# Altium schematic sheet CORE.SchDoc
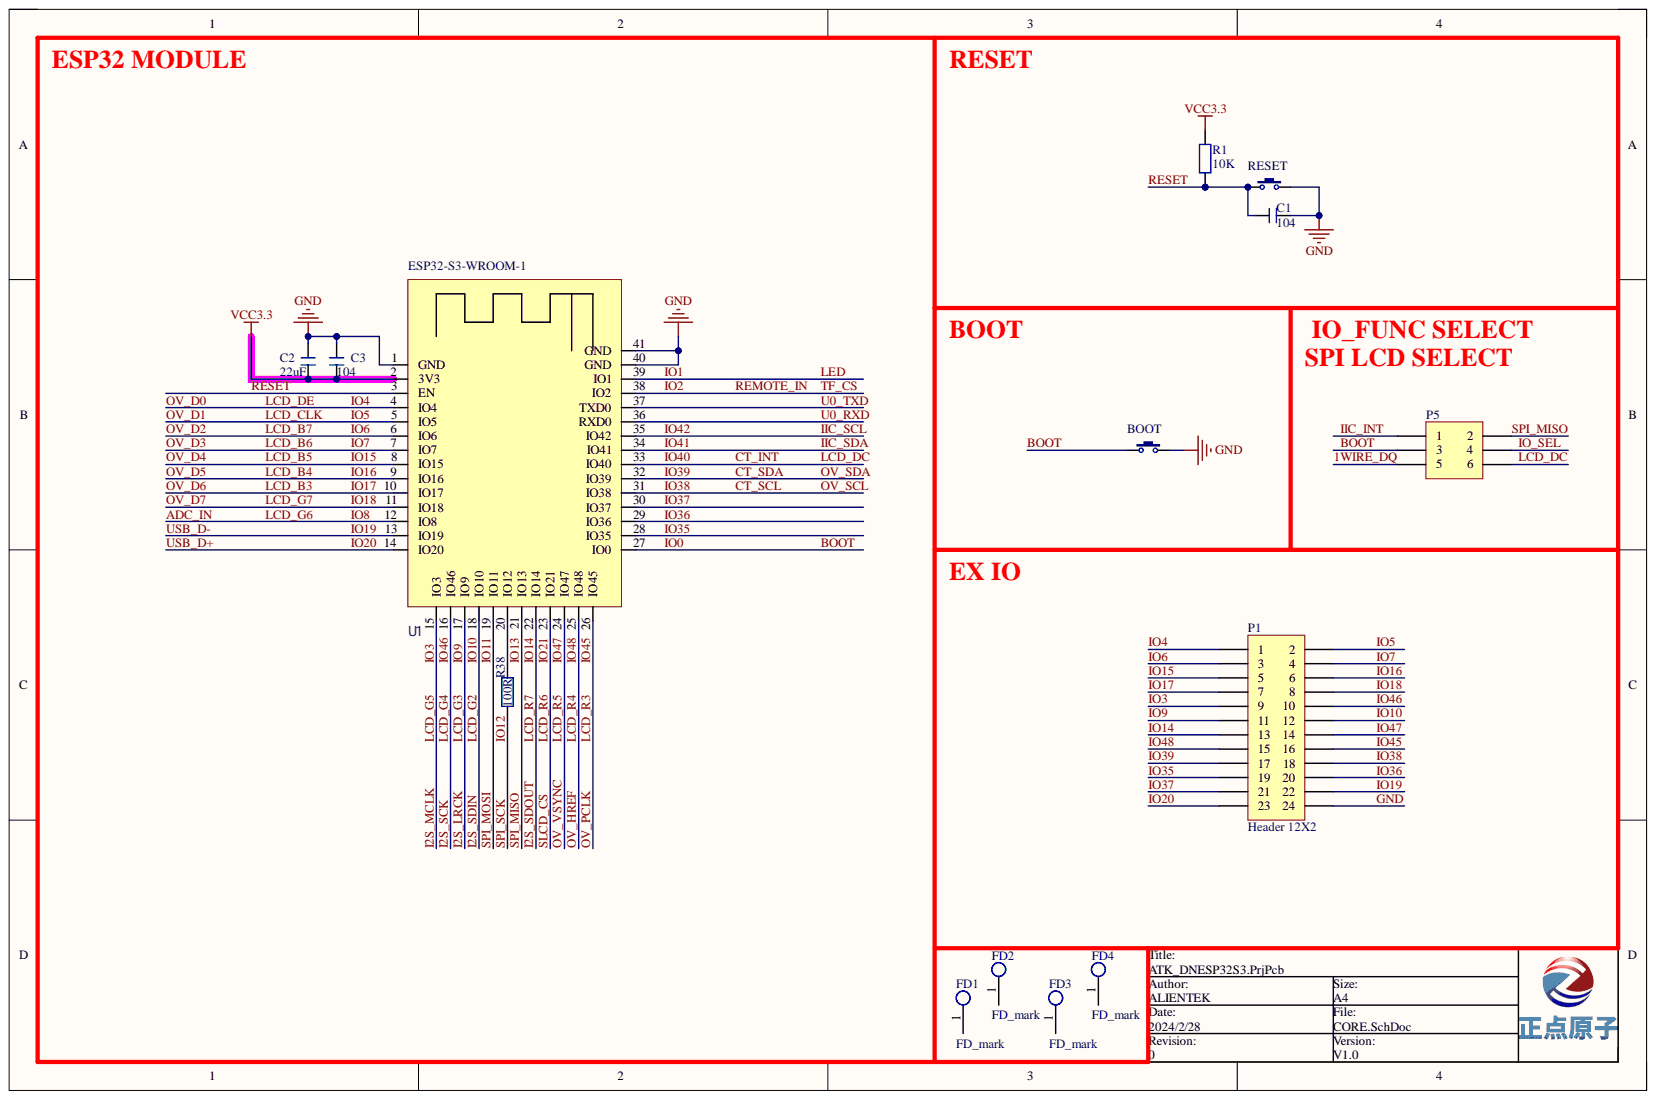
[diagram p0: CORE.SchDoc]

## Parts
BOOT  BOOT  [KEY 3.2*4.2*2.5 4P SMD]
C1  104  [C 0402]
C2  22uF  [C 0805]
C3  104  [C 0402]
FD1  FD_mark  [FD_MARK]
FD2  FD_mark  [FD_MARK]
FD3  FD_mark  [FD_MARK]
FD4  FD_mark  [FD_MARK]
P1  Header 12X2  [HDR2X12]
P5  HDR2X3  [HDR2X3]  (value not drawn)
R1  10K  [R 0402]
R38  100R  [R 0402]
RESET  RST  [KEY 3.2*4.2*2.5 4P SMD]  (value not drawn)
U1  ESP32-S3-WROOM-1  [ESP32-S3-WROOM]

## Nets
VCC3.3: C2.1 C3.1 R1.1 U1.2(3V3)
1WIRE_DQ: P5.5
ADC_IN: U1.12(IO8)
BOOT: BOOT.1 P5.3 U1.27(IO0)
CT_INT: P5.6 U1.33(IO40)
CT_SCL: P1.18 U1.31(IO38)
CT_SDA: P1.17 U1.32(IO39)
GND: BOOT.2 C1.1 C2.2 C3.2 P1.24 RESET.2 U1.1(GND) U1.40(GND) U1.41(GND)
I2S_LRCK: P1.11 U1.17(IO9)
I2S_MCLK: P1.9 U1.15(IO3)
I2S_SCK: P1.10 U1.16(IO46)
I2S_SDIN: P1.12 U1.18(IO10)
I2S_SDOUT: P1.13 U1.22(IO14)
IIC_INT: P5.1
IIC_SCL: U1.35(IO42)
IIC_SDA: U1.34(IO41)
IO1: U1.39(IO1)
IO2: U1.38(IO2)
IO4: P1.1 U1.4(IO4)
IO5: P1.2 U1.5(IO5)
IO6: P1.3 U1.6(IO6)
IO7: P1.4 U1.7(IO7)
IO11: U1.19(IO11)
IO12: R38.2
IO13: P5.2 U1.21(IO13)
IO15: P1.5 U1.8(IO15)
IO16: P1.6 U1.9(IO16)
IO17: P1.7 U1.10(IO17)
IO18: P1.8 U1.11(IO18)
IO19: P1.22 U1.13(IO19)
IO20: P1.23 U1.14(IO20)
IO21: U1.23(IO21)
IO35: P1.19 U1.28(IO35)
IO36: P1.20 U1.29(IO36)
IO37: P1.21 U1.30(IO37)
IO45: P1.16 U1.26(IO45)
IO47: P1.14 U1.24(IO47)
IO48: P1.15 U1.25(IO48)
IO_SEL: P5.4
RESET: C1.2 R1.2 RESET.1 U1.3(EN)
U0_RXD: U1.36(RXD0)
U0_TXD: U1.37(TXD0)
NetR38_1: R38.1 U1.20(IO12)

Not connected: FD1 1; FD2 1; FD3 1; FD4 1

## Library data of the parts
BOOT RESET = KEY: 按键; BOM名称: 3x6轻触开关; Parameter: 按键/开关; 版权归属: 正点原子; Component Kind: Standard; Library Name: 按键-开关.SchLib; 学习资源: www.openedv.com
C1-C3 = C: 无极性电容; BOM名称: 无极性贴片电容; 版权归属: 正点原子; Component Kind: Standard; 学习资源: www.openedv.com
  C1: Library Name: 电容.SchLib
  C2 C3: Library Name: 正点原子封装库V1.0.IntLib
FD1-FD4 = FD_mark: 光学定位点; Component Kind: Standard; Library Name: 正点原子封装库V1.0.IntLib
P1 = Header 12X2: Header, 12-Pin, Dual row; Component Kind: Standard; LatestRevisionDate: 17-Jul-2002; LatestRevisionNote: Re-released for DXP Platform.; Library Name: Miscellaneous Connectors.IntLib; Signal Integrity: Connector; Publisher: Altium Limited
P5 = Header 3X2: Header, 3-Pin, Dual row; Component Kind: Standard; LatestRevisionDate: 17-Jul-2002; LatestRevisionNote: Re-released for DXP Platform.; Library Name: Miscellaneous Connectors.IntLib; Publisher: Altium Limited
R1 R38 = R: 贴片电阻; 版权归属: 源创客; BOM名称: 贴片电阻; Component Kind: Standard; Library Name: 3.1   - 电阻-电容-电感.SchLib; 学习资源: www.ooocke.com
U1 = ESP32-S3-WROOM-1: Component Kind: Standard; Library Name: ATK_DNESP32S3.SCHLIB
